# Altium schematic sheet Sheet1.SchDoc(Sheet1)
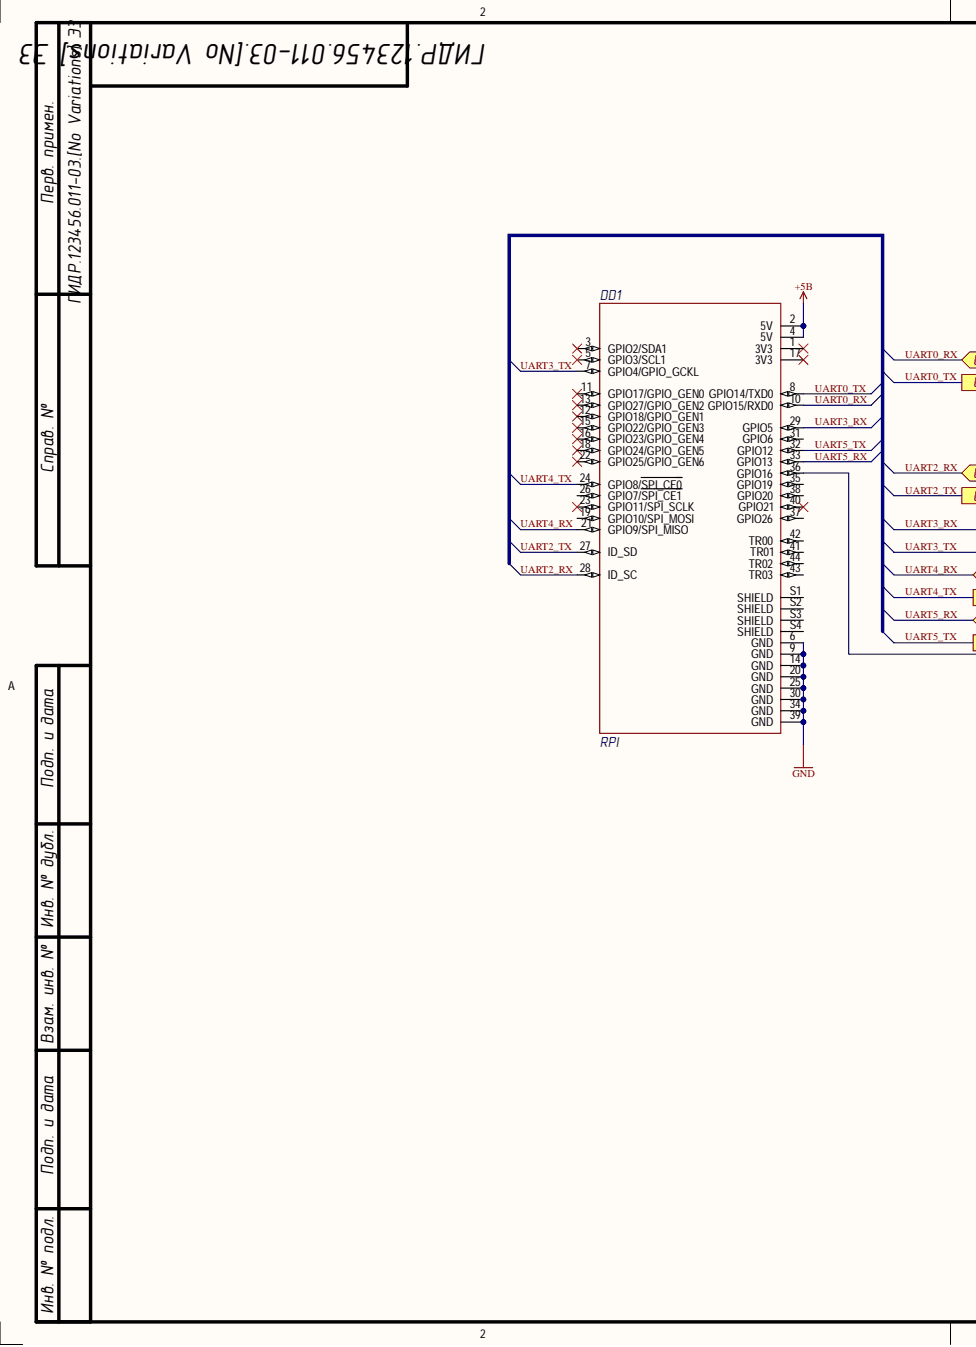
[diagram p0: Sheet1.SchDoc, left]
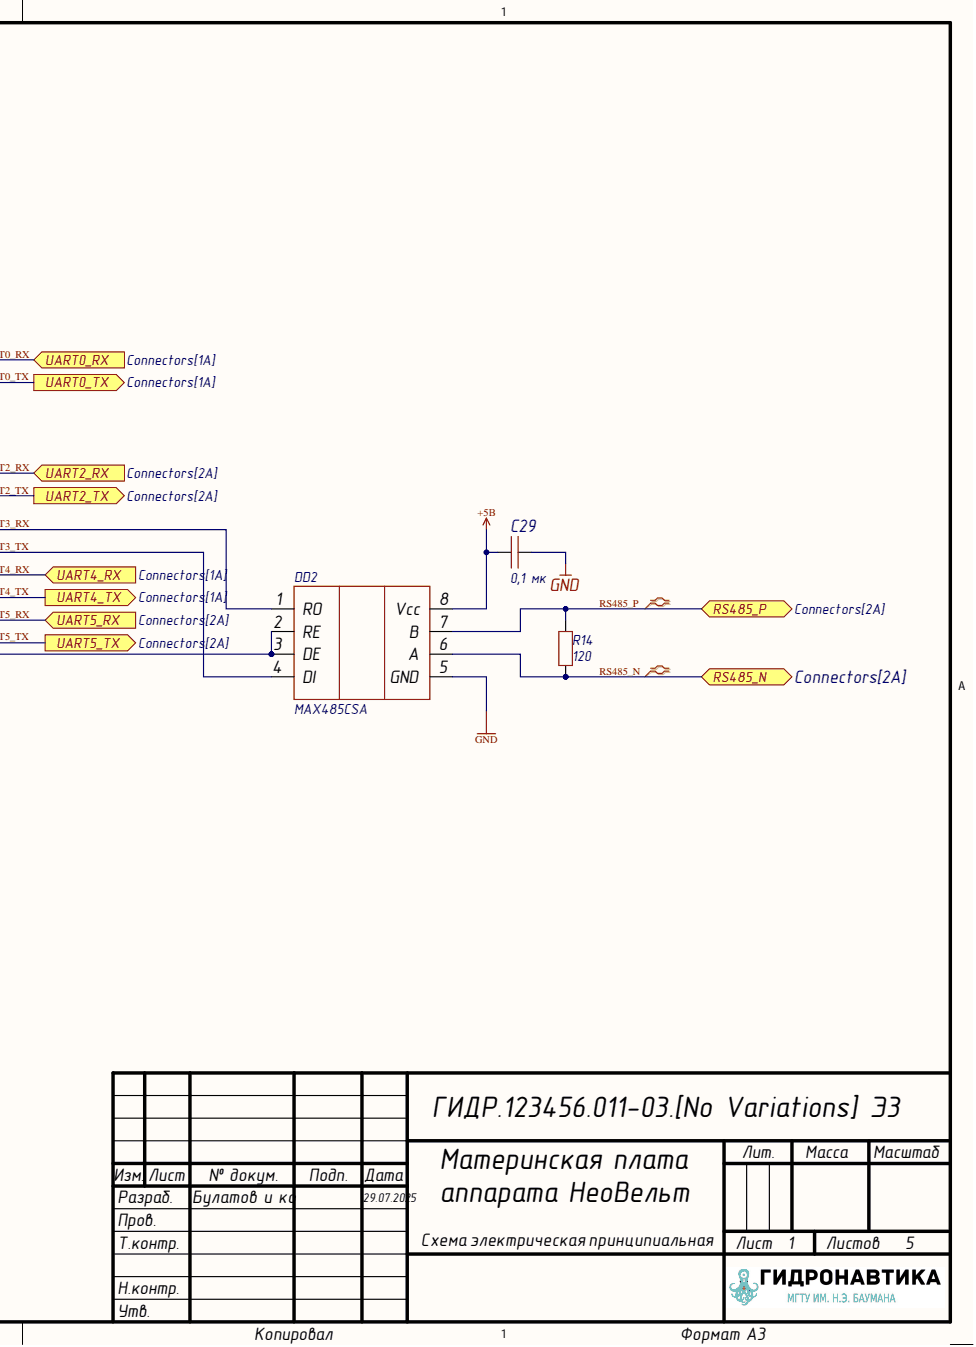
[diagram p1: Sheet1.SchDoc, right]

## Parts
C29  value 0,1 мк  [0603C]  (hidden comment: Capacitor)
DD1  RPI  [RPI]
DD2  MAX485CSA  [SOIC-8]
R14  value 120  [0603R]  (hidden comment: Resistor)

## Ports
RS485_N, RS485_P, UART0_RX, UART0_TX, UART2_RX, UART2_TX, UART4_RX, UART4_TX, UART5_RX, UART5_TX

## Nets
+5В: C29.1 DD1.2(5V) DD1.4(5V) DD2.8(Vcc)
GND: C29.2 DD1.6(GND) DD1.9(GND) DD1.14(GND) DD1.20(GND) DD1.25(GND) DD1.30(GND) DD1.34(GND) DD1.39(GND) DD2.5(GND)
RS485_N: DD2.6(A) R14.1 ; port RS485_N
RS485_P: DD2.7(B) R14.2 ; port RS485_P
UART0_RX: DD1.10(GPIO15/RXD0) ; port UART0_RX
UART0_TX: DD1.8(GPIO14/TXD0) ; port UART0_TX
UART2_RX: DD1.28(ID_SC) ; port UART2_RX
UART2_TX: DD1.27(ID_SD) ; port UART2_TX
UART3_RX: DD1.29(GPIO5) DD2.1(RO)
UART3_TX: DD1.7(GPIO4/GPIO_GCKL) DD2.4(DI)
UART4_RX: DD1.21(GPIO9/SPI_MISO) ; port UART4_RX
UART4_TX: DD1.24(GPIO8/SPI_CE0) ; port UART4_TX
UART5_RX: DD1.33(GPIO13) ; port UART5_RX
UART5_TX: DD1.32(GPIO12) ; port UART5_TX
NetDD1_36: DD1.36(GPIO16) DD2.2(RE) DD2.3(DE)

Not connected: DD1 1(3V3) 3(GPIO2/SDA1) 5(GPIO3/SCL1) 11(GPIO17/GPIO_GEN0) 12(GPIO18/GPIO_GEN1) 13(GPIO27/GPIO_GEN2) 15(GPIO22/GPIO_GEN3) 16(GPIO23/GPIO_GEN4) 17(3V3) 18(GPIO24/GPIO_GEN5) 19(GPIO10/SPI_MOSI) 22(GPIO25/GPIO_GEN6) 23(GPIO11/SPI_SCLK) 26(GPIO7/SPI_CE1) 31(GPIO6) 35(GPIO19) 37(GPIO26) 38(GPIO20) 40(GPIO21) 41(TR01) 42(TR00) 43(TR03) 44(TR02) S1(SHIELD) S2(SHIELD) S3(SHIELD) S4(SHIELD)

## Library data of the parts
C29 = Capacitor_0,1u_10_50V_X7R_0603C: Конденсатор 0603C ёмкостью 0,1 мк 10%, 50 В, X7R; Capacity (nF): 100; Database Table Name: Capacitors_ceramic$; Voltage (V): 50; Component Kind: Standard; Dielectric: X7R; Library Name: Hydrolib.DbLib; Part Number: Capacitor_0,1u_10_50V_X7R_0603C; Tolerance: 10%
DD1 = RPI: Одноплатный компьютер Raspberry PI 4B; Database Table Name: Chips$; Component Kind: Standard; Library Name: Hydrolib.DbLib; Part Number: RPI
DD2 = MAX485CSA: RS-485 приёмопередатчик; Database Table Name: Chips$; Component Kind: Standard; Library Name: Hydrolib.DbLib; Part Number: MAX485CSA
R14 = Resistor_120_1_0603: Резистор номиналом 120 Ом и точностью 1%; Database Table Name: Resistors$; Component Kind: Standard; Library Name: Hydrolib.DbLib; Part Number: Resistor_120_1_0603; Tolerance: 1%
